# Altium schematic sheet UTS.SchDoc
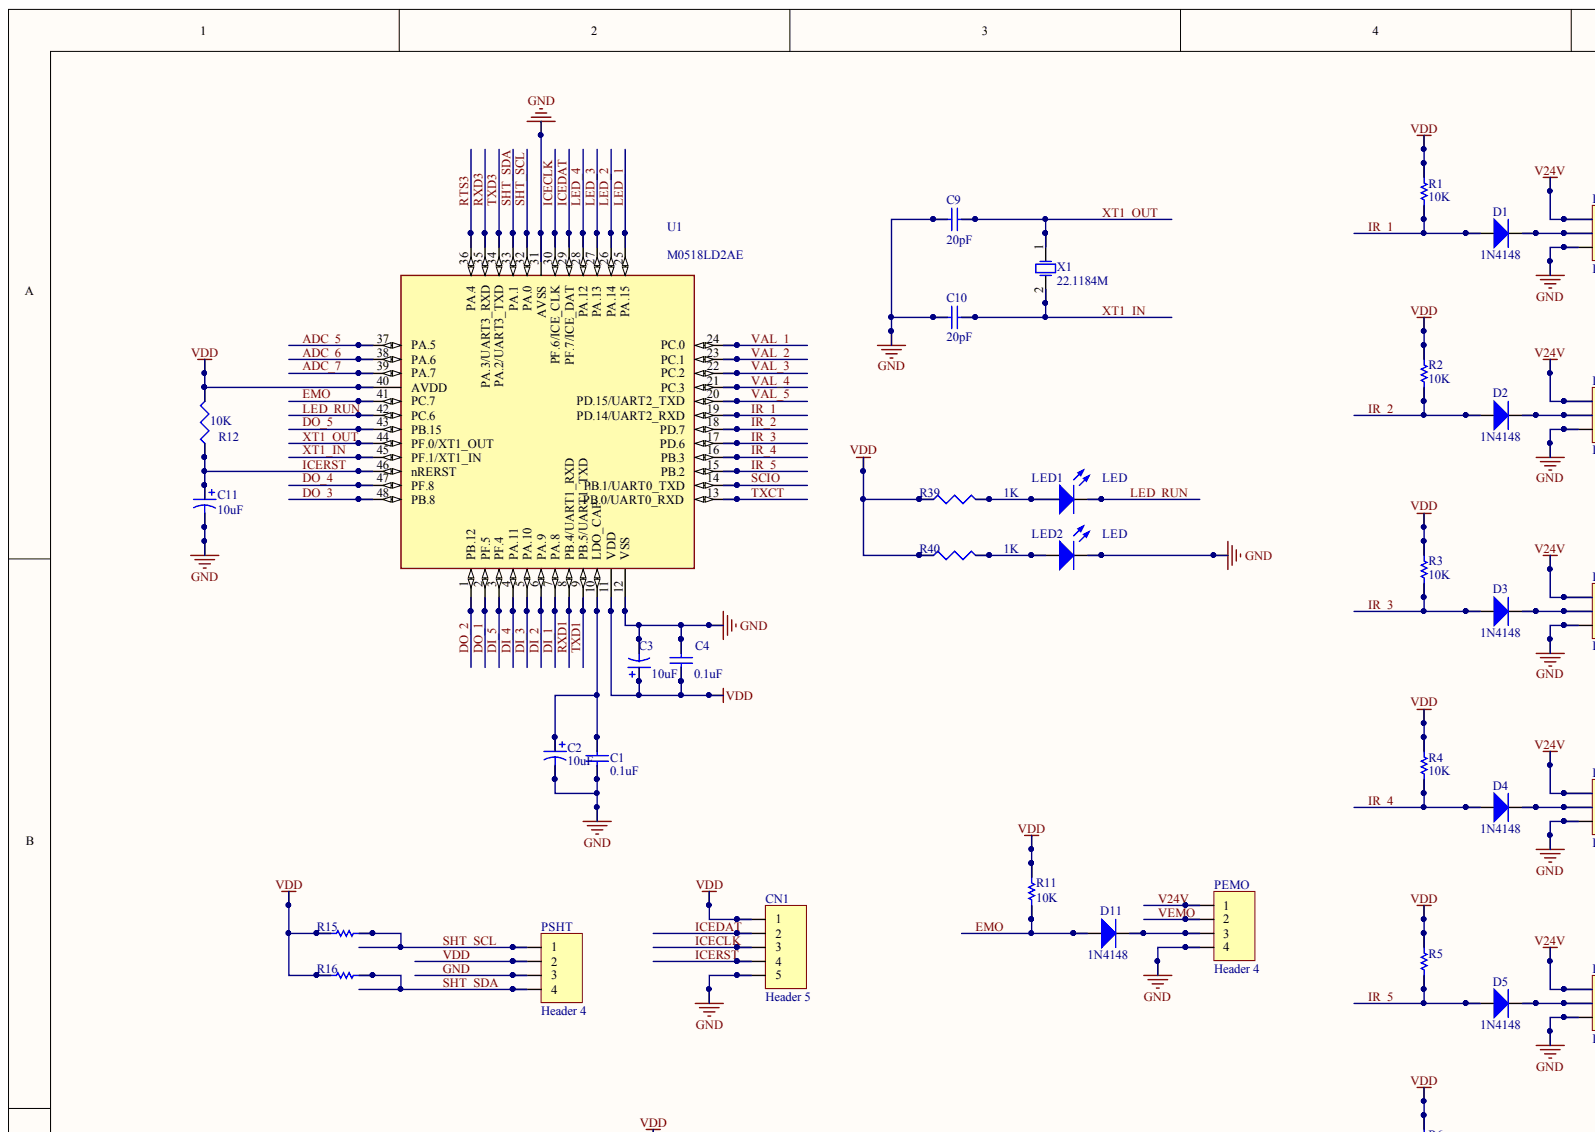
[diagram p0: UTS.SchDoc, top left]
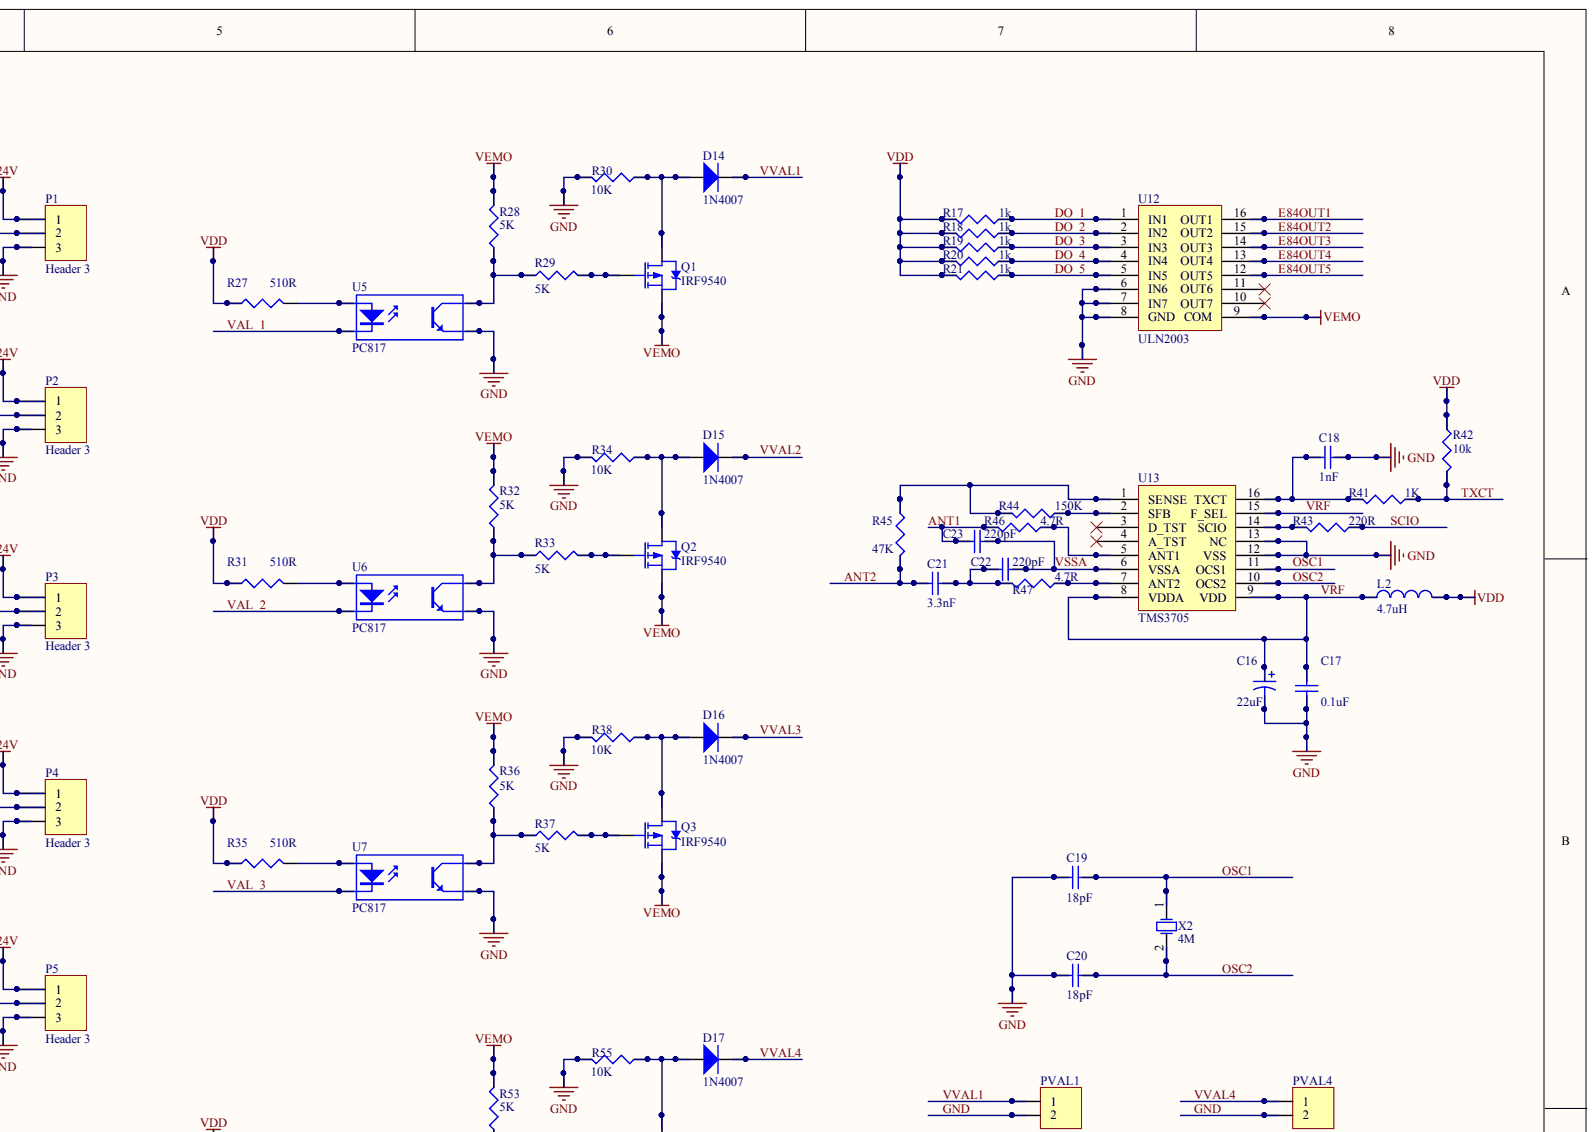
[diagram p1: UTS.SchDoc, top right]
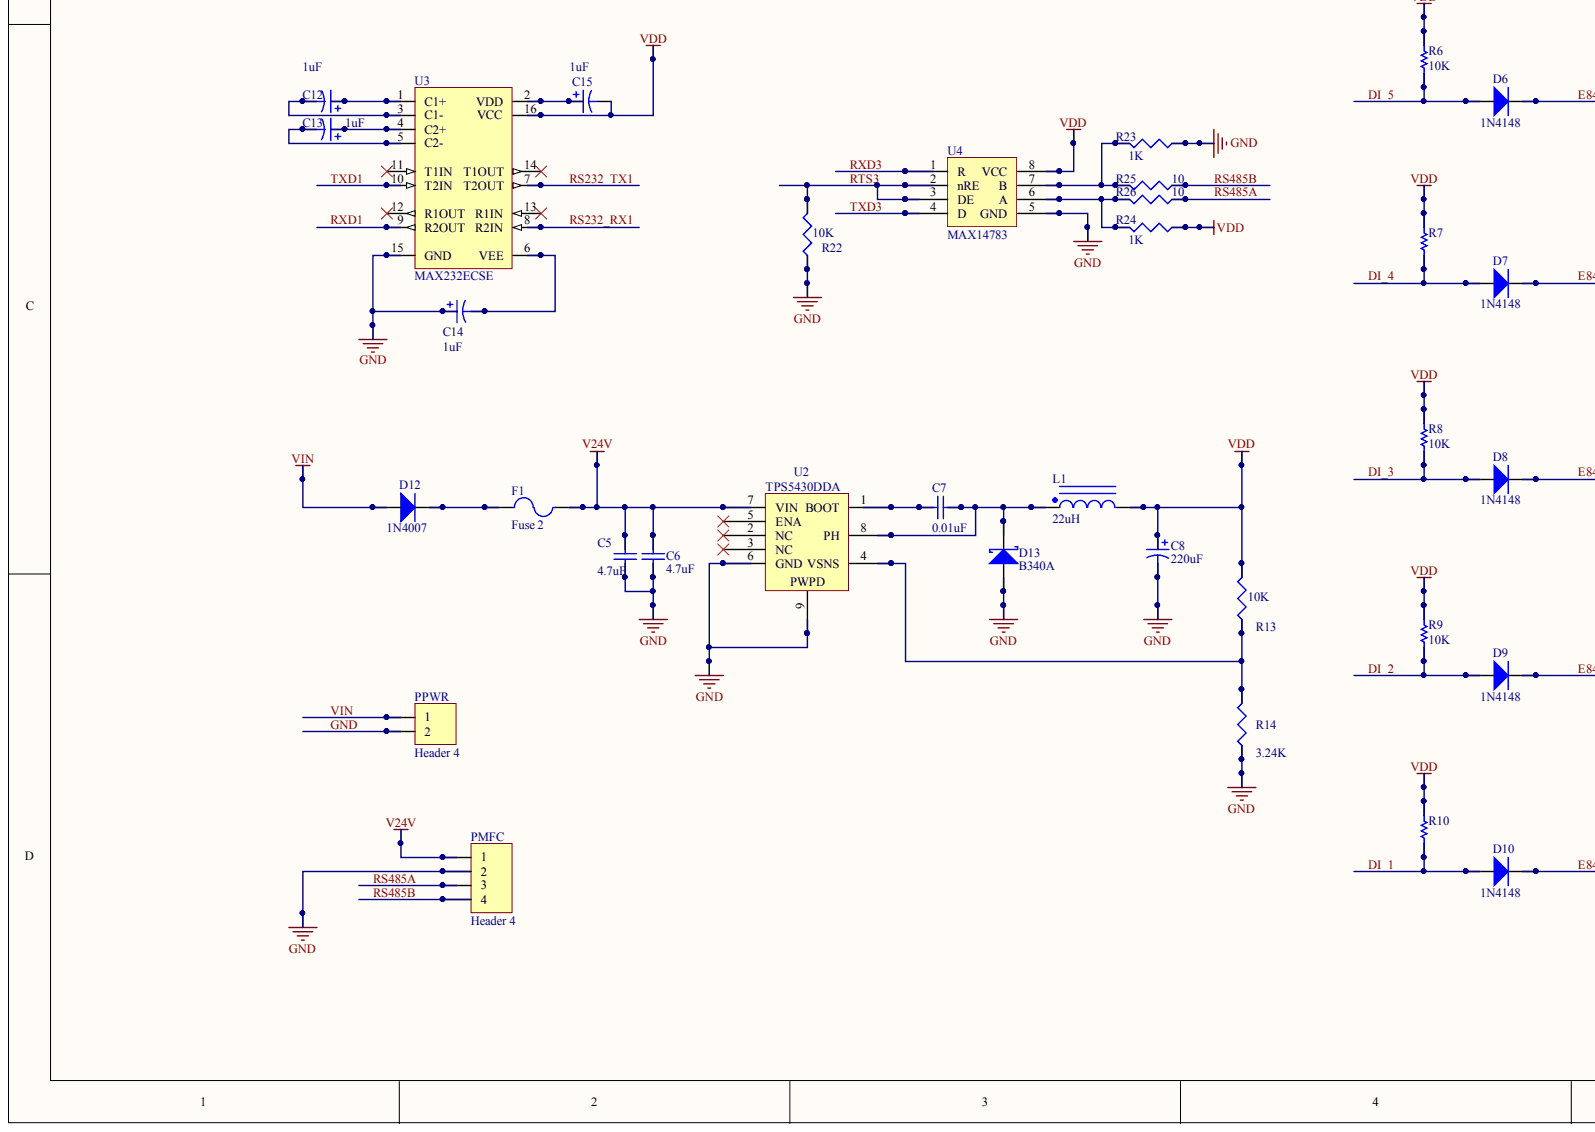
[diagram p2: UTS.SchDoc, bottom left]
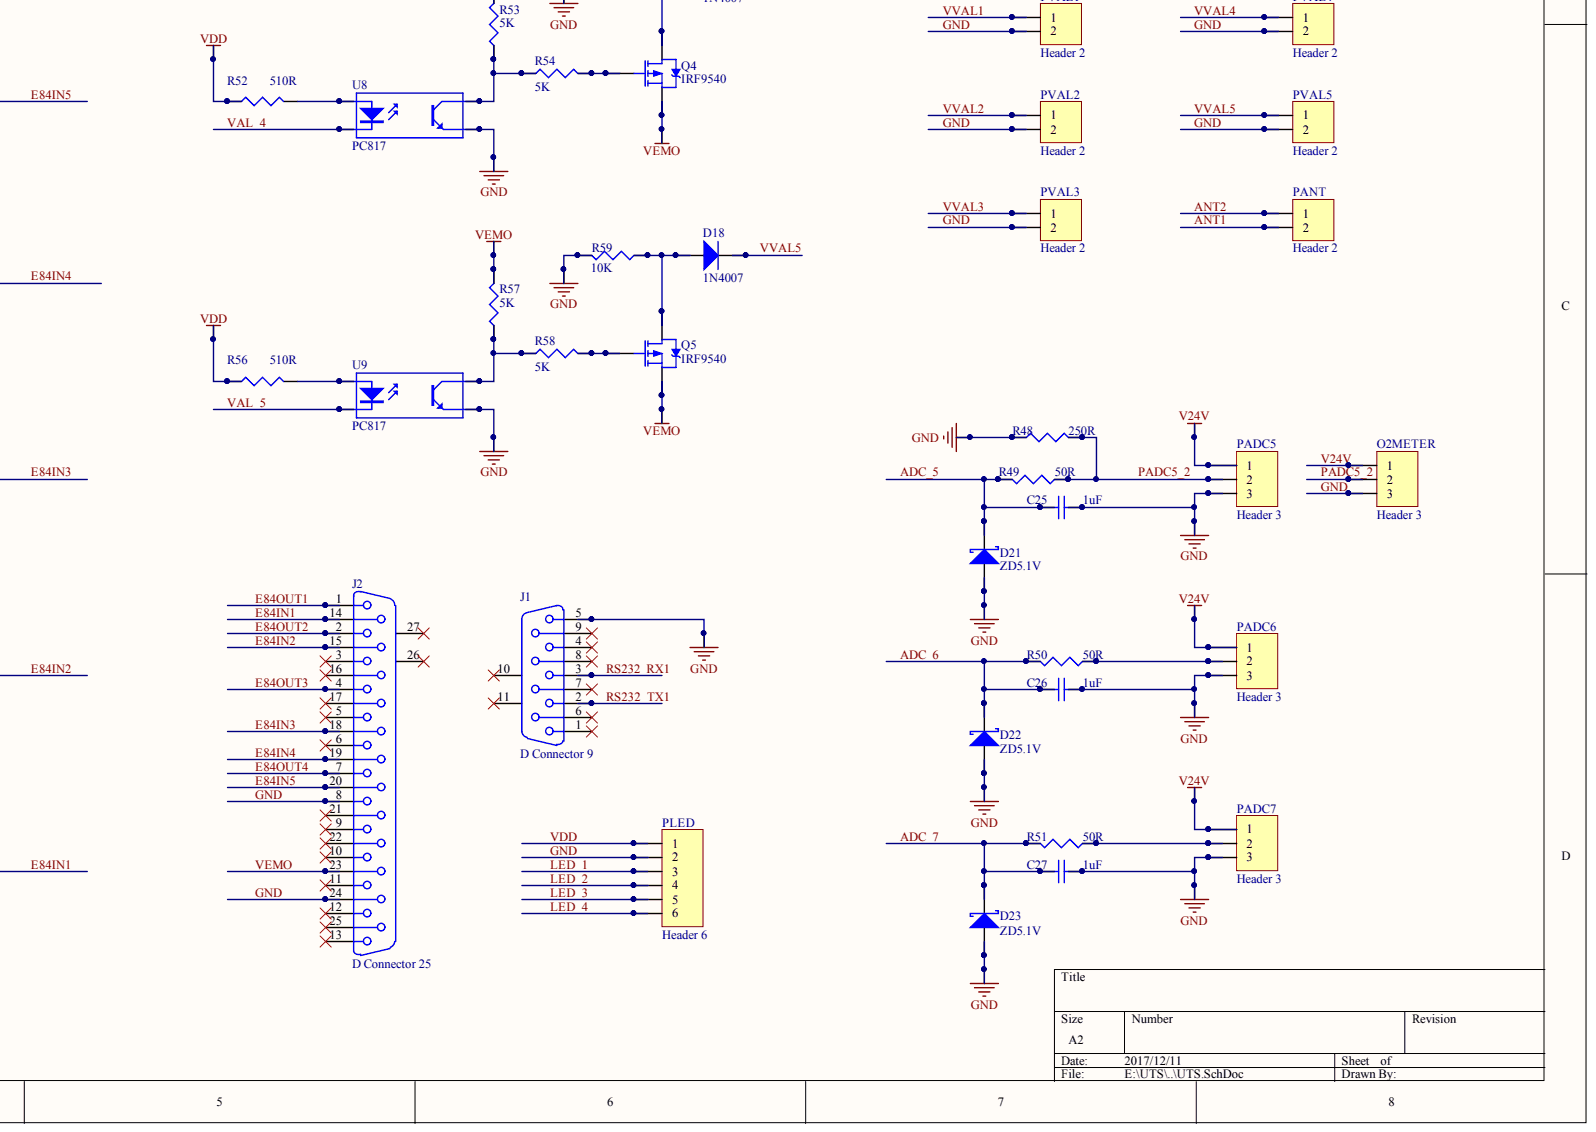
[diagram p3: UTS.SchDoc, bottom right]

## Parts
C1  0.1uF  [C0603]
C2  10uF  [C3216]
C3  10uF  [C3216]
C4  0.1uF  [C0603]
C5  4.7uF  [C0603]
C6  4.7uF  [C0603]
C7  0.01uF  [C0603]
C8  220uF  [C10X12]
C9  20pF  [C0603]
C10  20pF  [C0603]
C11  10uF  [C3216]
C12  1uF  [C0603]
C13  1uF  [C0603]
C14  1uF  [C0603]
C15  1uF  [C0603]
C16  22uF  [C3216]
C17  0.1uF  [C0603]
C18  1nF  [C0603]
C19  18pF  [C0603]
C20  18pF  [C0603]
C21  3.3nF  [CAPR5-4X5]
C22  220pF  [C0603]
C23  220pF  [C0603]
C25  1uF  [C0603]
C26  1uF  [C0603]
C27  1uF  [C0603]
CN1  Header 5  [HDR1X5]
D1  1N4148  [SOD123]
D2  1N4148  [SOD123]
D3  1N4148  [SOD123]
D4  1N4148  [SOD123]
D5  1N4148  [SOD123]
D6  1N4148  [SOD123]
D7  1N4148  [SOD123]
D8  1N4148  [SOD123]
D9  1N4148  [SOD123]
D10  1N4148  [SOD123]
D11  1N4148  [SOD123]
D12  1N4007  [SMB]
D13  B340A  [SMB]
D14  1N4007  [SMB]
D15  1N4007  [SMB]
D16  1N4007  [SMB]
D17  1N4007  [SMB]
D18  1N4007  [SMB]
D21  ZD5.1V  [SOD123]
D22  ZD5.1V  [SOD123]
D23  ZD5.1V  [SOD123]
F1  Fuse 2  [FUSE1]
J1  D Connector 9  [DSUB1.385-2H9]
J2  D Connector 25  [DSUB1.385-2H25A]
L1  22uH  [L12X12]
L2  4.7uH  [L4532]
LED1  LED  [D0805]
LED2  LED  [D0805]
O2METER  Header 3  [3P-3.5-90]
P1  Header 3  [3P-3.5-90]
P2  Header 3  [3P-3.5-90]
P3  Header 3  [3P-3.5-90]
P4  Header 3  [3P-3.5-90]
P5  Header 3  [3P-3.5-90]
PADC5  Header 3  [XH2.54-3]
PADC6  Header 3  [XH2.54-3]
PADC7  Header 3  [XH2.54-3]
PANT  Header 2  [2P-3.5-90]
PEMO  Header 4  [4P-3.5-90]
PLED  Header 6  [XH2.54-6]
PMFC  Header 4  [4P-3.5-90]
PPWR  Header 4  [2P-3.5-90]
PSHT  Header 4  [XH2.54-4]
PVAL1  Header 2  [2P-3.5-90]
PVAL2  Header 2  [2P-3.5-90]
PVAL3  Header 2  [2P-3.5-90]
PVAL4  Header 2  [2P-3.5-90]
PVAL5  Header 2  [2P-3.5-90]
Q1  IRF9540  [TO220AB]
Q2  IRF9540  [TO220AB]
Q3  IRF9540  [TO220AB]
Q4  IRF9540  [TO220AB]
Q5  IRF9540  [TO220AB]
R1  10K  [R0603]
R2  10K  [R0603]
R3  10K  [R0603]
R4  10K  [R0603]
R5  10K  [R0603]  (value not drawn)
R6  10K  [R0603]
R7  10K  [R0603]  (value not drawn)
R8  10K  [R0603]
R9  10K  [R0603]
R10  10K  [R0603]  (value not drawn)
R11  10K  [R0603]
R12  10K  [R0603]
R13  10K  [R0603]
R14  3.24K  [R0603]
R15  10K  [R0603]  (value not drawn)
R16  10K  [R0603]  (value not drawn)
R17  1k  [R0603]
R18  1k  [R0603]
R19  1k  [R0603]
R20  1k  [R0603]
R21  1k  [R0603]
R22  10K  [R0603]
R23  1K  [R0603]
R24  1K  [R0603]
R25  10  [R0603]
R26  10  [R0603]
R27  510R  [R0603]
R28  5K  [R0603]
R29  5K  [R0603]
R30  10K  [R0603]
R31  510R  [R0603]
R32  5K  [R0603]
R33  5K  [R0603]
R34  10K  [R0603]
R35  510R  [R0603]
R36  5K  [R0603]
R37  5K  [R0603]
R38  10K  [R0603]
R39  1K  [R0603]
R40  1K  [R0603]
R41  1K  [R0603]
R42  10k  [R0603]
R43  220R  [R0603]
R44  150K  [R0603]
R45  47K  [R0603]
R46  4.7R  [R1206]
R47  4.7R  [R1206]
R48  250R  [R1206]
R49  50R  [R0603]
R50  50R  [R0603]
R51  50R  [R0603]
R52  510R  [R0603]
R53  5K  [R0603]
R54  5K  [R0603]
R55  10K  [R0603]
R56  510R  [R0603]
R57  5K  [R0603]
R58  5K  [R0603]
R59  10K  [R0603]
U1  M0518LD2AE  [TQFP48]
U2  TPS5430DDA  [SO8E]
U3  MAX232ECSE  [SOP16]
U4  MAX14783  [SOP8]
U5  PC817  [EL-817]
U6  PC817  [EL-817]
U7  PC817  [EL-817]
U8  PC817  [EL-817]
U9  PC817  [EL-817]
U12  ULN2003  [SOP16]
U13  TMS3705  [SOP16]
X1  22.1184M  [49S-SMD]
X2  4M  [49S-SMD]

## Nets
ADC_5: C25.1 D21.2 R49.2 U1.37(PA.5)
ADC_6: C26.1 D22.2 R50.2 U1.38(PA.6)
ADC_7: C27.1 D23.2 R51.2 U1.39(PA.7)
ANT1: C23.1 PANT.2 R46.2
ANT2: C21.2 PANT.1 R45.2
DI_1: D10.1 R10.1 U1.7(PA.8)
DI_2: D9.1 R9.1 U1.6(PA.9)
DI_3: D8.1 R8.1 U1.5(PA.10)
DI_4: D7.1 R7.1 U1.4(PA.11)
DI_5: D6.1 R6.1 U1.3(PF.4)
DO_1: R17.1 U1.2(PF.5) U12.1(IN1)
DO_2: R18.1 U1.1(PB.12) U12.2(IN2)
DO_3: R19.1 U1.48(PB.8) U12.3(IN3)
DO_4: R20.1 U1.47(PF.8) U12.4(IN4)
DO_5: R21.1 U1.43(PB.15) U12.5(IN5)
E84IN1: D10.2 J2.14
E84IN2: D9.2 J2.15
E84IN3: D8.2 J2.18
E84IN4: D7.2 J2.19
E84IN5: D6.2 J2.20
E84OUT1: J2.1 U12.16(OUT1)
E84OUT2: J2.2 U12.15(OUT2)
E84OUT3: J2.4 U12.14(OUT3)
E84OUT4: J2.7 U12.13(OUT4)
E84OUT5: U12.12(OUT5)
EMO: D11.1 R11.1 U1.41(PC.7)
GND: C1.1 C2.2 C3.2 C4.1 C5.1 C6.1 C8.2 C9.2 C10.2 C11.2 C14.1 C16.2 C17.1 C18.1 C19.2 C20.2 C25.2 C26.2 C27.2 CN1.5 D13.1 D21.1 D22.1 D23.1 J1.5 J2.8 J2.24 LED2.2 O2METER.3 P1.3 P2.3 P3.3 P4.3 P5.3 PADC5.3 PADC6.3 PADC7.3 PEMO.4 PLED.2 PMFC.2 PPWR.2 PSHT.3 PVAL1.2 PVAL2.2 PVAL3.2 PVAL4.2 PVAL5.2 R14.1 R22.1 R23.1 R30.2 R34.2 R38.2 R48.1 R55.2 R59.2 U1.12(VSS) U1.31(AVSS) U2.6(GND) U2.9 U3.15(GND) U4.5(GND) U5.3 U6.3 U7.3 U8.3 U9.3 U12.6(IN6) U12.7(IN7) U12.8(GND) U13.12(VSS) U13.13(NC)
ICECLK: CN1.3 U1.30(PF.6/ICE_CLK)
ICEDAT: CN1.2 U1.29(PF.7/ICE_DAT)
ICERST: C11.1 CN1.4 R12.1 U1.46(nRERST)
IR_1: D1.1 R1.1 U1.19(PD.14/UART2_RXD)
IR_2: D2.1 R2.1 U1.18(PD.7)
IR_3: D3.1 R3.1 U1.17(PD.6)
IR_4: D4.1 R4.1 U1.16(PB.3)
IR_5: D5.1 R5.1 U1.15(PB.2)
LED_1: PLED.3 U1.25(PA.15)
LED_2: PLED.4 U1.26(PA.14)
LED_3: PLED.5 U1.27(PA.13)
LED_4: PLED.6 U1.28(PA.12)
LED_RUN: LED1.2 U1.42(PC.6)
OSC1: C19.1 U13.11(OCS1) X2.1
OSC2: C20.1 U13.10(OCS2) X2.2
PADC5_2: O2METER.2 PADC5.2 R48.2 R49.1
RS232_RX1: J1.3 U3.8(R2IN)
RS232_TX1: J1.2 U3.7(T2OUT)
RS485A: PMFC.3 R26.1
RS485B: PMFC.4 R25.1
RTS3: R22.2 U1.36(PA.4) U4.2(nRE) U4.3(DE)
RXD1: U1.8(PB.4/UART1_RXD) U3.9(R2OUT)
RXD3: U1.35(PA.3/UART3_RXD) U4.1(R)
SCIO: R43.1 U1.14(PB.1/UART0_TXD)
SHT_SCL: PSHT.1 R15.1 U1.32(PA.0)
SHT_SDA: PSHT.4 R16.1 U1.33(PA.1)
TXCT: R41.1 R42.2 U1.13(PB.0/UART0_RXD)
TXD1: U1.9(PB.5/UART1_TXD) U3.10(T2IN)
TXD3: U1.34(PA.2/UART3_TXD) U4.4(D)
V24V: C5.2 C6.2 F1.2 O2METER.1 P1.1 P2.1 P3.1 P4.1 P5.1 PADC5.1 PADC6.1 PADC7.1 PEMO.1 PMFC.1 U2.7(VIN)
VAL_1: U1.24(PC.0) U5.2
VAL_2: U1.23(PC.1) U6.2
VAL_3: U1.22(PC.2) U7.2
VAL_4: U1.21(PC.3) U8.2
VAL_5: U1.20(PD.15/UART2_TXD) U9.2
VDD: C3.1 C4.2 C8.1 C15.2 CN1.1 L1.2 L2.2 PLED.1 PSHT.2 R1.2 R2.2 R3.2 R4.2 R5.2 R6.2 R7.2 R8.2 R9.2 R10.2 R11.2 R12.2 R13.2 R15.2 R16.2 R17.2 R18.2 R19.2 R20.2 R21.2 R24.1 R27.1 R31.1 R35.1 R39.1 R40.1 R42.1 R52.1 R56.1 U1.11(VDD) U1.40(AVDD) U3.16(VCC) U4.8(VCC)
VEMO: J2.23 PEMO.2 Q1.3 Q2.3 Q3.3 Q4.3 Q5.3 R28.2 R32.2 R36.2 R53.2 R57.2 U12.9(COM)
VIN: D12.1 PPWR.1
VRF: C16.1 C17.2 L2.1 U13.8(VDDA) U13.9(VDD) U13.15(F_SEL)
VSSA: C22.2 C23.2 U13.6(VSSA)
VVAL1: D14.2 PVAL1.1
VVAL2: D15.2 PVAL2.1
VVAL3: D16.2 PVAL3.1
VVAL4: D17.2 PVAL4.1
VVAL5: D18.2 PVAL5.1
XT1_IN: C10.1 U1.45(PF.1/XT1_IN) X1.2
XT1_OUT: C9.1 U1.44(PF.0/XT1_OUT) X1.1
NetC1_2: C1.2 C2.1 U1.10(LDO_CAP)
NetC7_1: C7.1 D13.2 L1.1 U2.8(PH)
NetC7_2: C7.2 U2.1(BOOT)
NetC12_1: C12.1 U3.1(C1+)
NetC12_2: C12.2 U3.3(C1-)
NetC13_1: C13.1 U3.4(C2+)
NetC13_2: C13.2 U3.5(C2-)
NetC14_2: C14.2 U3.6(VEE)
NetC15_1: C15.1 U3.2(VDD)
NetC18_2: C18.2 R41.2 U13.16(TXCT)
NetC21_1: C21.1 C22.1 R47.2
NetD1_2: D1.2 P1.2
NetD2_2: D2.2 P2.2
NetD3_2: D3.2 P3.2
NetD4_2: D4.2 P4.2
NetD5_2: D5.2 P5.2
NetD11_2: D11.2 PEMO.3
NetD12_2: D12.2 F1.1
NetD14_1: D14.1 Q1.2 R30.1
NetD15_1: D15.1 Q2.2 R34.1
NetD16_1: D16.1 Q3.2 R38.1
NetD17_1: D17.1 Q4.2 R55.1
NetD18_1: D18.1 Q5.2 R59.1
NetLED1_1: LED1.1 R39.2
NetLED2_1: LED2.1 R40.2
NetPADC6_2: PADC6.2 R50.1
NetPADC7_2: PADC7.2 R51.1
NetQ1_1: Q1.1 R29.2
NetQ2_1: Q2.1 R33.2
NetQ3_1: Q3.1 R37.2
NetQ4_1: Q4.1 R54.2
NetQ5_1: Q5.1 R58.2
NetR13_1: R13.1 R14.2 U2.4(VSNS)
NetR23_2: R23.2 R25.2 U4.7(B)
NetR24_2: R24.2 R26.2 U4.6(A)
NetR27_2: R27.2 U5.1
NetR28_1: R28.1 R29.1 U5.4
NetR31_2: R31.2 U6.1
NetR32_1: R32.1 R33.1 U6.4
NetR35_2: R35.2 U7.1
NetR36_1: R36.1 R37.1 U7.4
NetR43_2: R43.2 U13.14(SCIO)
NetR44_1: R44.1 U13.2(SFB)
NetR44_2: R44.2 R45.1 U13.1(SENSE)
NetR46_1: R46.1 U13.5(ANT1)
NetR47_1: R47.1 U13.7(ANT2)
NetR52_2: R52.2 U8.1
NetR53_1: R53.1 R54.1 U8.4
NetR56_2: R56.2 U9.1
NetR57_1: R57.1 R58.1 U9.4

Not connected: J1 1 4 6 7 8 9 10 11; J2 3 5 6 9 10 11 12 13 16 17 21 22 25 26 27; U2 2(NC) 3(NC) 5(ENA); U3 11(T1IN) 12(R1OUT) 13(R1IN) 14(T1OUT); U12 10(OUT7) 11(OUT6); U13 3(D_TST) 4(A_TST)

## Library data of the parts
C1 C4-C7 C9 C10 C17-C23 C25-C27 = Cap Semi: Capacitor (Semiconductor SIM Model); Component Kind: Standard; LatestRevisionDate: 29-May-2009; LatestRevisionNote: IPC-7351 Footprint Added.; Library Name: Miscellaneous Devices.IntLib; PackageDescription: Chip Capacitor; PackageReference: C1206; Published: 8-Jun-2000; Signal Integrity: Cap Semi; Simulation: CAP; Publisher: Altium Limited
  C1 C4 C17: Value: 0.1uF
  C5 C6: Value: 4.7uF
  C7: Value: 0.01uF
  C9 C10: Value: 20pF
  C18: Value: 1nF
  C19 C20: Value: 18pF
  C21: Value: 3.3nF
  C22 C23: Value: 220pF
  C25-C27: Value: 1uF
C2 C3 C8 C11-C16 = Cap Pol3: Polarized Capacitor (Surface Mount); Component Kind: Standard; LatestRevisionDate: 29-May-2009; LatestRevisionNote: IPC-7351 Footprint Added.; Library Name: Miscellaneous Devices.IntLib; PackageDescription: Chip Capacitor; PackageReference: C0805; Published: 8-Jun-2000; Signal Integrity: Cap Pol; Simulation: CAP; Publisher: Altium Limited
  C2 C3 C11: Value: 10uF
  C8: Value: 220uF
  C12-C15: Value: 1uF
  C16: Value: 22uF
CN1 = Header 5: Header, 5-Pin; Component Kind: Standard; LatestRevisionDate: 17-Jul-2002; LatestRevisionNote: Re-released for DXP Platform.; Library Name: Miscellaneous Connectors.IntLib; Signal Integrity: Connector; Publisher: Altium Limited
D1-D12 D14-D18 = Diode 1N4007: 1 Amp General Purpose Rectifier; Code_JEDEC: DO-204-AL; Component Kind: Standard; ComponentLink1Description: Manufacturer Link; ComponentLink1URL: http://www.fairchildsemi.com/; ComponentLink2Description: Datasheet; ComponentLink2URL: http://www.fairchildsemi.com/ds/1N%2F1N4001.pdf; DatasheetVersion: 1998; LatestRevisionDate: 17-Jul-2002; LatestRevisionNote: Re-released for DXP Platform.; Library Name: Miscellaneous Devices.IntLib; PackageDescription: Diode, Axial; Body 5.2 x 2.7 mm (LxDia. max), Lead Dia. 0.86 mm (max); PackageReference: DO-41; PackageVersion: Aug-1999; Published: 8-Jun-2000; Signal Integrity: 1N4007; Simulation: 1N4007; Publisher: Altium Limited
D13 = D Schottky: Schottky Diode; Code_JEDEC: DO-214-AA; Component Kind: Standard; LatestRevisionDate: 17-Jul-2002; LatestRevisionNote: Re-released for DXP Platform.; Library Name: Miscellaneous Devices.IntLib; PackageDescription: DO-214-AA/SMB; 2 C-Bend Leads; Body 5.3 x 3.6 mm, inc. leads (LxW); PackageReference: SMB; Published: 8-Jun-2000; Signal Integrity: D Schottky; Simulation: SKYDIODE; Publisher: Altium Limited
D21-D23 = Diode 11DQ03: Schottky Rectifier; Code_JEDEC: DO-204-AL; Component Kind: Standard; ComponentLink1Description: Manufacturer Link; ComponentLink1URL: http://www.irf.com/; ComponentLink2Description: Datasheet; ComponentLink2URL: http://www.irf.com/product-info/datasheets/data/11dq03.pdf; DatasheetVersion: Dec-1997; LatestRevisionDate: 17-Jul-2002; LatestRevisionNote: Re-released for DXP Platform.; Library Name: Miscellaneous Devices.IntLib; PackageDescription: Diode, Axial; Body 5.2 x 2.7 mm (LxDia. max), Lead Dia. 0.86 mm (max); PackageReference: DO-204AL; Published: 8-Jun-2000; Simulation: 11DQ03; Publisher: Altium Limited
F1 = Fuse 2: Fuse; Component Kind: Standard; LatestRevisionDate: 17-Jul-2002; LatestRevisionNote: Re-released for DXP Platform.; Library Name: Miscellaneous Devices.IntLib; PackageDescription: Thru-Hole; 2 Leads; PackageReference: PIN-W2/E2.8; Published: 8-Jun-2000; Simulation: FUSE; Publisher: Altium Limited
J1 = D Connector 9: Receptacle Assembly, 9 Position, Right Angle; PackageDocument: O; Positions: 9; Component Kind: Standard; DatasheetDocument: 1-Sep-1999; Gender: Receptacle; LatestRevisionDate: 17-Jul-2002; LatestRevisionNote: Re-released for DXP Platform.; Library Name: Miscellaneous Connectors.IntLib; Orientation: Right Angle; PackageReference: 788750; Published: 15-Jun-2001; Signal Integrity: Connector; Publisher: Altium Limited
J2 = D Connector 25: Receptacle Assembly, 25 Position, Right Angle; PackageDocument: U; Positions: 25; Component Kind: Standard; DatasheetDocument: 19-Mar-1999; Gender: Receptacle; LatestRevisionDate: 17-Jul-2002; LatestRevisionNote: Re-released for DXP Platform.; Library Name: Miscellaneous Connectors.IntLib; Orientation: Right Angle; PackageReference: 787202; Published: 15-Jun-2001; Signal Integrity: Connector; Publisher: Altium Limited
L1 = Inductor Iron Dot: Magnetic-Core Inductor with Winding Polarity Marking; Component Kind: Standard; LatestRevisionDate: 17-Jul-2002; LatestRevisionNote: Re-released for DXP Platform.; Library Name: Miscellaneous Devices.IntLib; PackageDescription: Diode; 2 Leads; PackageReference: DIODE_SMC; Published: 24-Mar-1999; Signal Integrity: Inductor Iron Dot; Value: 22uH; Publisher: Altium Limited
L2 = Inductor: Code_IEC: 1005; Code_JEITA: 0402; Component Kind: Standard; Description: Inductor; LatestRevisionDate: 29-May-2009; LatestRevisionNote: IPC-7351 Footprint Added.; Library Name: Miscellaneous Devices.IntLib; PackageDescription: Chip Inductor; PackageReference: 0402-A; Published: 8-Jun-2000; Signal Integrity: Inductor; Simulation: INDUCTOR; Value: 4.7uH; Publisher: Altium Limited
LED1 LED2 = LED1: Typical RED GaAs LED; Component Kind: Standard; LatestRevisionDate: 08-Jul-2005; LatestRevisionNote: Mech Layer 1 removed.; Library Name: Miscellaneous Devices.IntLib; PackageDescription: LED; 2 Leads; PackageReference: LED-1; Published: 8-Jun-2000; Simulation: LED1; Publisher: Altium Limited
O2METER P1-P5 PADC5-PADC7 = Header 3: Header, 3-Pin; Component Kind: Standard; LatestRevisionDate: 17-Jul-2002; LatestRevisionNote: Re-released for DXP Platform.; Library Name: Miscellaneous Connectors.IntLib; Signal Integrity: Connector; Publisher: Altium Limited
PANT PPWR PVAL1-PVAL5 = Header 2: Header, 2-Pin; Component Kind: Standard; LatestRevisionDate: 17-Jul-2002; LatestRevisionNote: Re-released for DXP Platform.; Library Name: Miscellaneous Connectors.IntLib; Signal Integrity: Connector; Publisher: Altium Limited
PEMO PMFC PSHT = Header 4: Header, 4-Pin; Component Kind: Standard; LatestRevisionDate: 17-Jul-2002; LatestRevisionNote: Re-released for DXP Platform.; Library Name: Miscellaneous Connectors.IntLib; Signal Integrity: Connector; Publisher: Altium Limited
PLED = Header 6: Header, 6-Pin; Component Kind: Standard; LatestRevisionDate: 17-Jul-2002; LatestRevisionNote: Re-released for DXP Platform.; Library Name: Miscellaneous Connectors.IntLib; Signal Integrity: Connector; Publisher: Altium Limited
Q1-Q5 = PMOS-2: P-Channel Power MOSFET; Note: Pin 4 not drawn and electrically connected to Pin 2.; Component Kind: Standard; ComponentLink1Description: Manufacturer Link; ComponentLink1URL: http://www.irf.com/; DatasheetVersion: PD-9.390D; LatestRevisionDate: 17-Jul-2002; LatestRevisionNote: Re-released for DXP Platform.; Library Name: Miscellaneous Devices.IntLib; PackageDescription: TO, Thru-Hole, Vertical, Heatsink Mounted; 3 In-Line Leads; Pitch 2.54 mm; PackageReference: TO-220AB; PackageVersion: Feb-1997; Published: 8-Jun-2000; Simulation: IRF9510; Publisher: Altium Limited
R1-R11 R15 R16 = Res1: Resistor; Component Kind: Standard; LatestRevisionDate: 17-Jul-2002; LatestRevisionNote: Re-released for DXP Platform.; Library Name: Miscellaneous Devices.IntLib; PackageDescription: Axial Device, Thru-Hole; 2 Leads; 0.3 in Pin Spacing; PackageReference: AXIAL-0.3; Published: 8-Jun-2000; Signal Integrity: Res; Simulation: RESISTOR; Value: 10K; Publisher: Altium Limited
R12-R14 R17-R59 = Res3: Resistor; Component Kind: Standard; LatestRevisionDate: 29-May-2009; LatestRevisionNote: IPC-7351 Footprint Added.; Library Name: Miscellaneous Devices.IntLib; PackageDescription: Chip Resistor; PackageReference: J1-0603; Published: 8-Jun-2000; Signal Integrity: Res; Simulation: RESISTOR; Publisher: Altium Limited
  R12 R13 R22 R30 R34 R38 R55 R59: Value: 10K
  R14: Value: 3.24K
  R17-R21: Value: 1k
  R23 R24 R39-R41: Value: 1K
  R25 R26: Value: 10
  R27 R31 R35 R52 R56: Value: 510R
  R28 R29 R32 R33 R36 R37 R53 R54 R57 R58: Value: 5K
  R42: Value: 10k
  R43: Value: 220R
  R44: Value: 150K
  R45: Value: 47K
  R46 R47: Value: 4.7R
  R48: Value: 250R
  R49-R51: Value: 50R
U3 = MAX232AEPE: +5V Powered, Multi-Channel RS-232 Driver/Receiver; Code_JEDEC: MS-001-BB; Component Kind: Standard; ComponentLink1Description: Manufacturer Link; ComponentLink1URL: http://www.maxim-ic.com/; ComponentLink2Description: Datasheet; ComponentLink2URL: http://pdfserv.maxim-ic.com/en/ds/MAX220-MAX249.pdf; LatestRevisionDate: 29-May-2009; LatestRevisionNote: Component Body Added.; Library Name: Maxim Communication Transceiver.IntLib; PackageDescription: DIP, 16-Pin, Row Spacing 7.62 mm, Pitch 2.54 mm; PackageReference: PE16A; PackageVersion: 1997; PCB3D: PE16; Published: 16-Jan-2001; Publisher: Altium Limited
U4 = VP3085: Component Kind: Standard; Library Name: VP3085.SchLib
U5-U9 = Optoisolator1: 4-Pin Phototransistor Optocoupler; Component Kind: Standard; DatasheetVersion: Nov-2003; LatestRevisionDate: 04-Mar-2005; LatestRevisionNote: Sch pins 3 & 4 Swapped.; Library Name: Miscellaneous Devices.IntLib; PackageReference: DIP-4; Published: 24-Mar-1999; Publisher: Altium Limited
X1 X2 = XTAL: Crystal Oscillator; Component Kind: Standard; LatestRevisionDate: 17-Jul-2002; LatestRevisionNote: Re-released for DXP Platform.; Library Name: Miscellaneous Devices.IntLib; PackageDescription: Crystal, Thru-Hole; 2 Leads; Body 3.1 x 8.2 mm (Dia.xH); PackageReference: R38; Published: 8-Jun-2000; Simulation: XTAL; Publisher: Altium Limited
U1 = M0518LD2AE: Component Kind: Standard; Library Name: M0518_48.SchLib
U2 = TPS5430DDA: Component Kind: Standard; Library Name: TPS5430.SchLib
U12 = ULN2003: Component Kind: Standard; Library Name: Schlib1.SchLib
U13 = TMS3705: Component Kind: Standard; Library Name: Schlib1.SchLib
